# Altium schematic sheet HANDSFREE_miniControl2.0.SchDoc
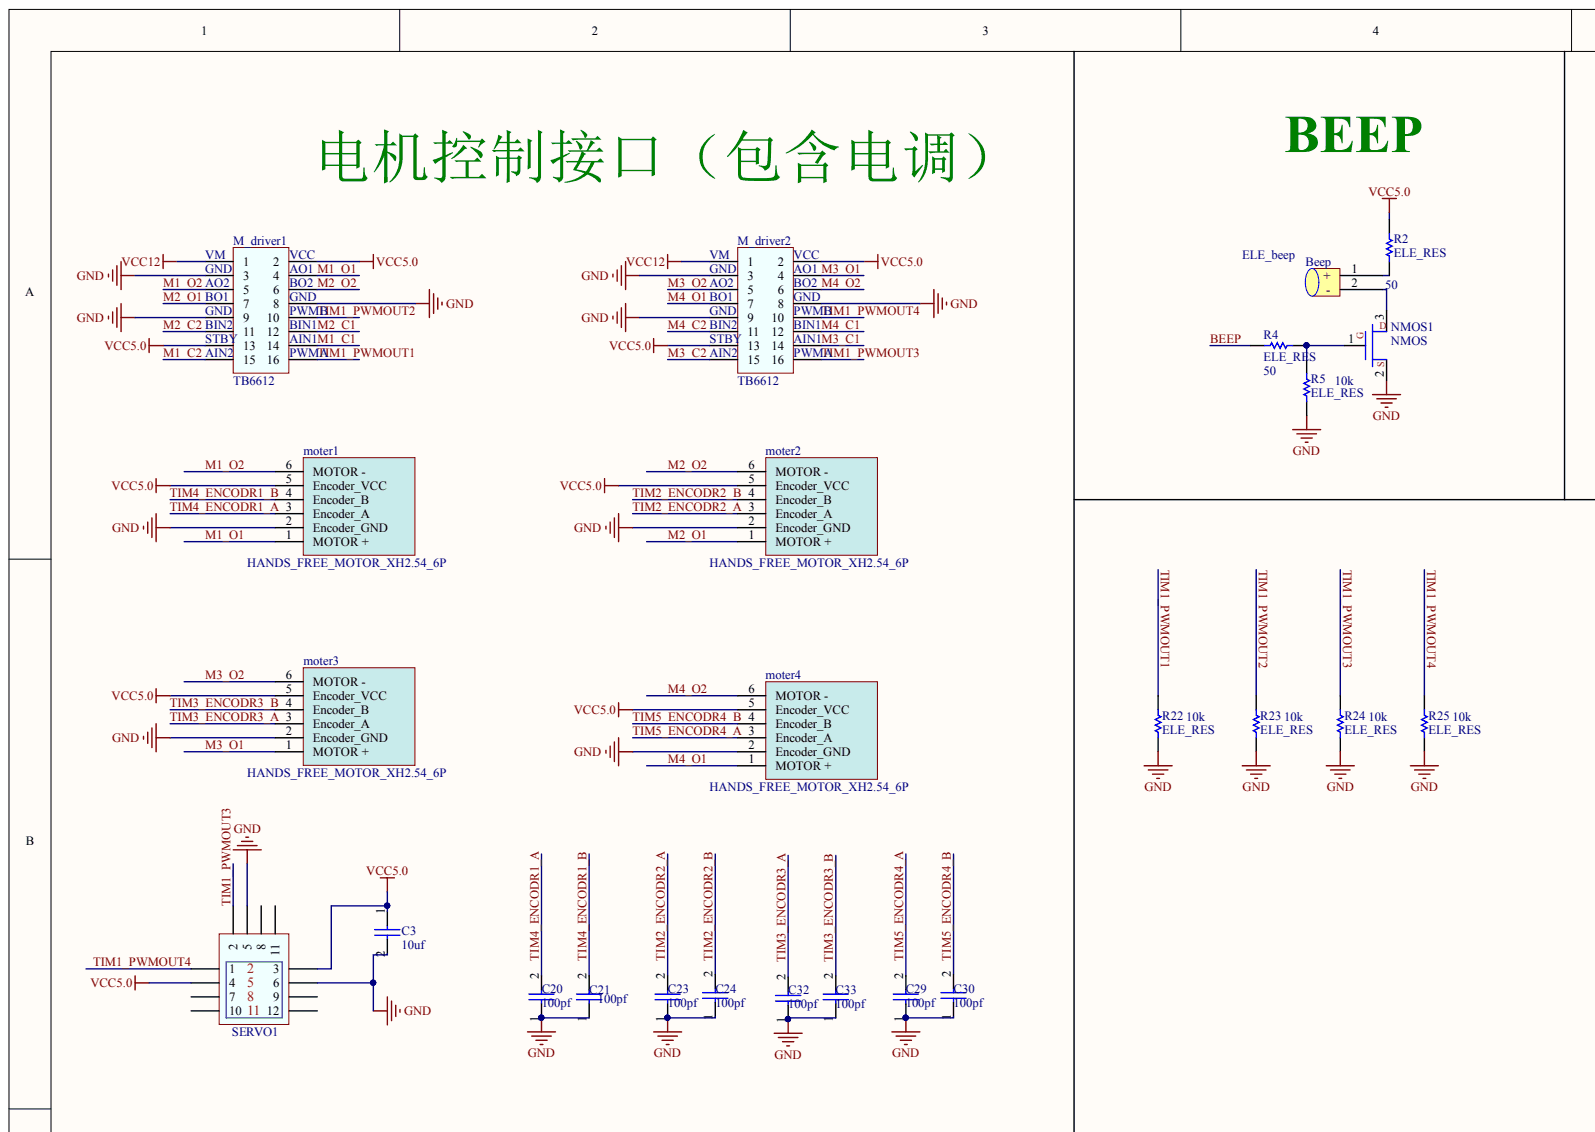
[diagram p0: HANDSFREE_miniControl2.0.SchDoc, top left]
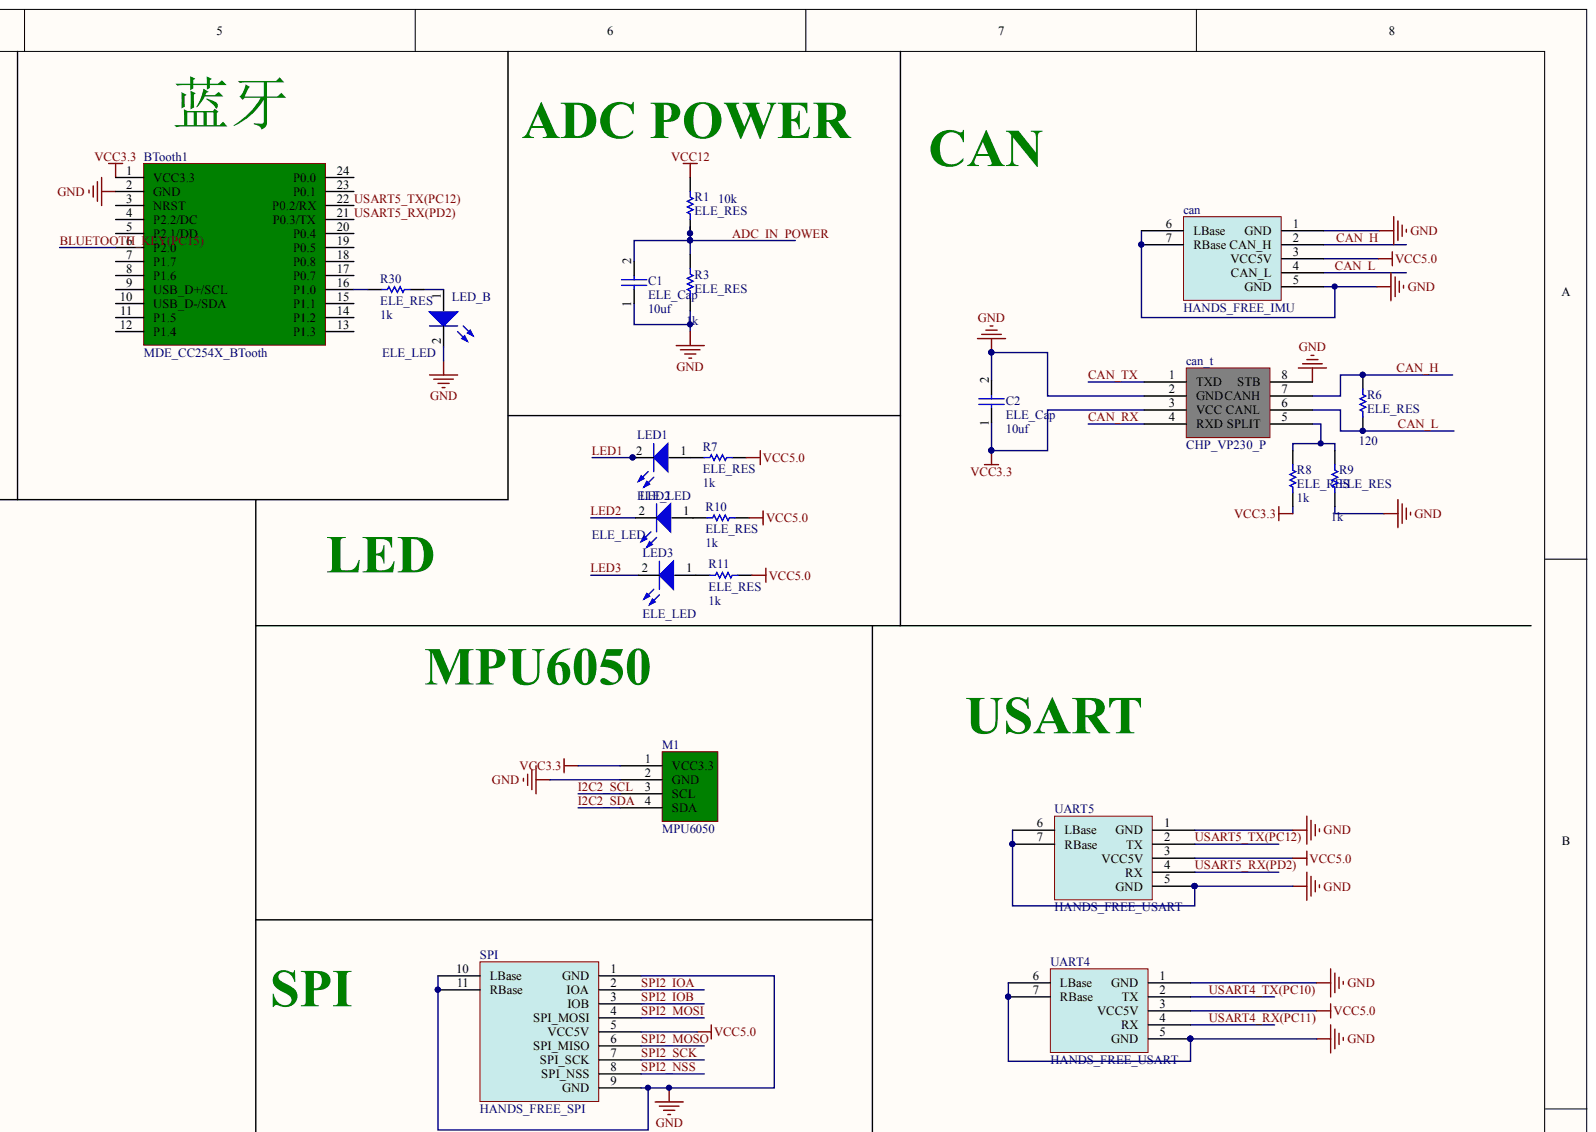
[diagram p1: HANDSFREE_miniControl2.0.SchDoc, top right]
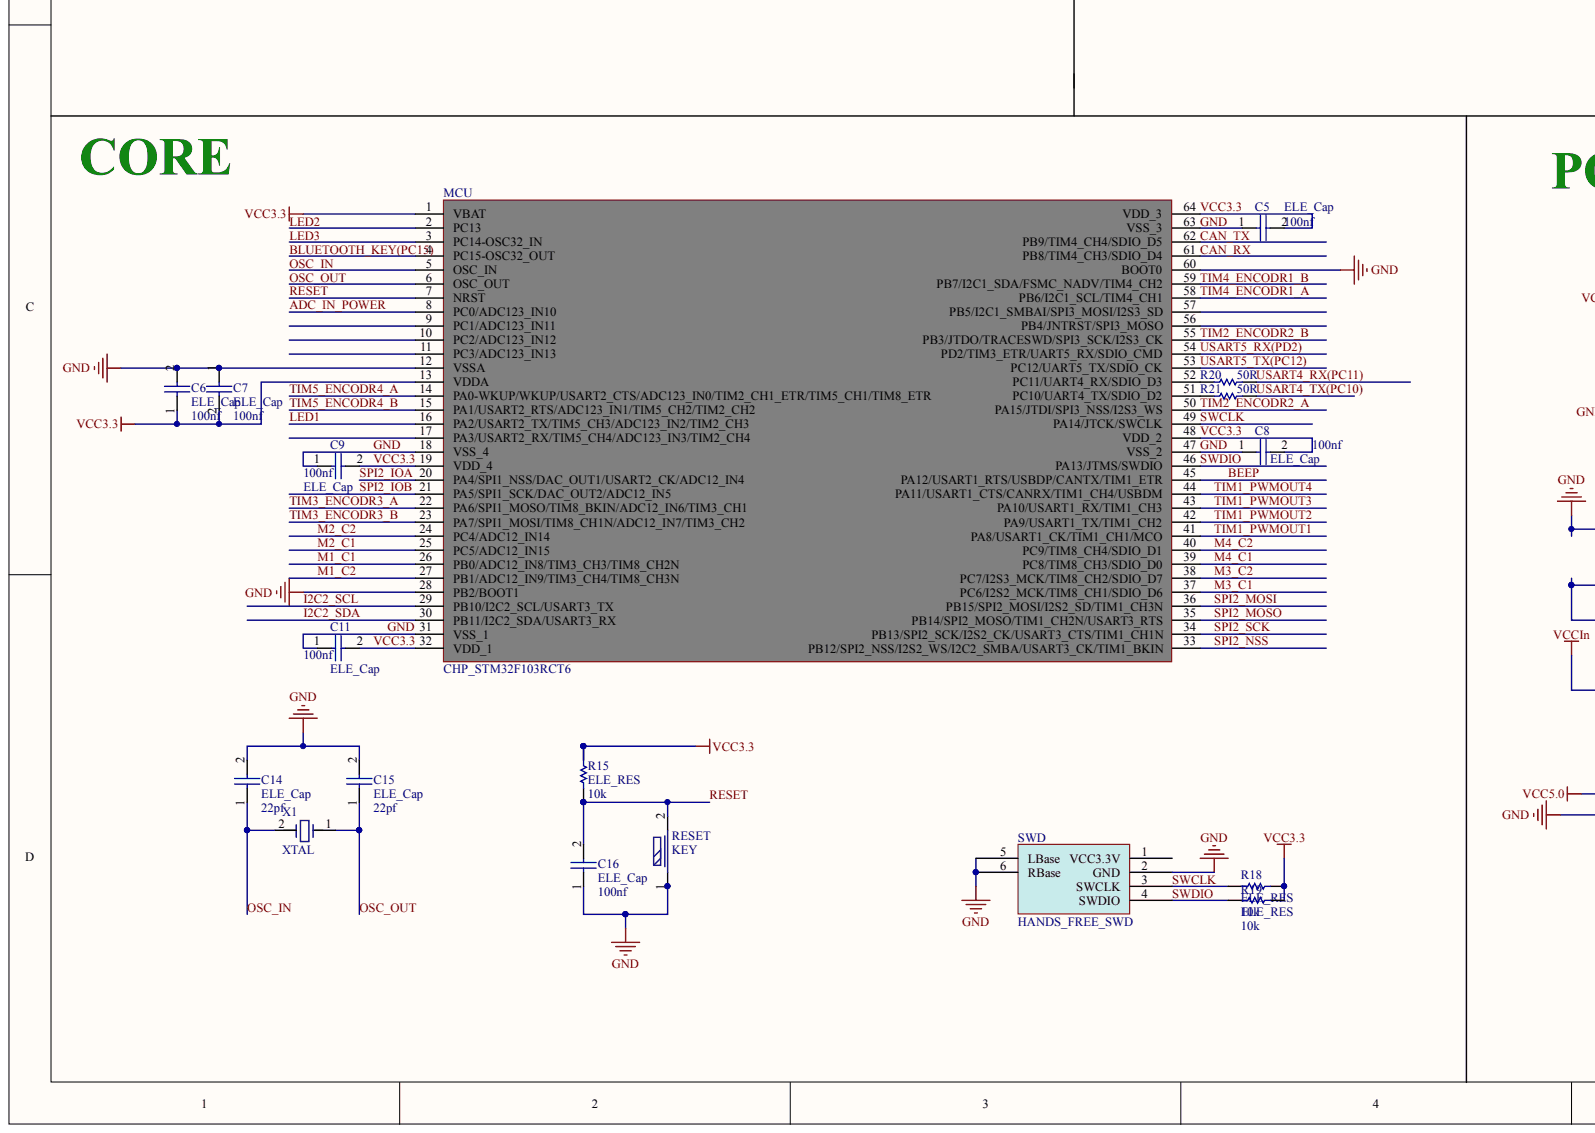
[diagram p2: HANDSFREE_miniControl2.0.SchDoc, bottom left]
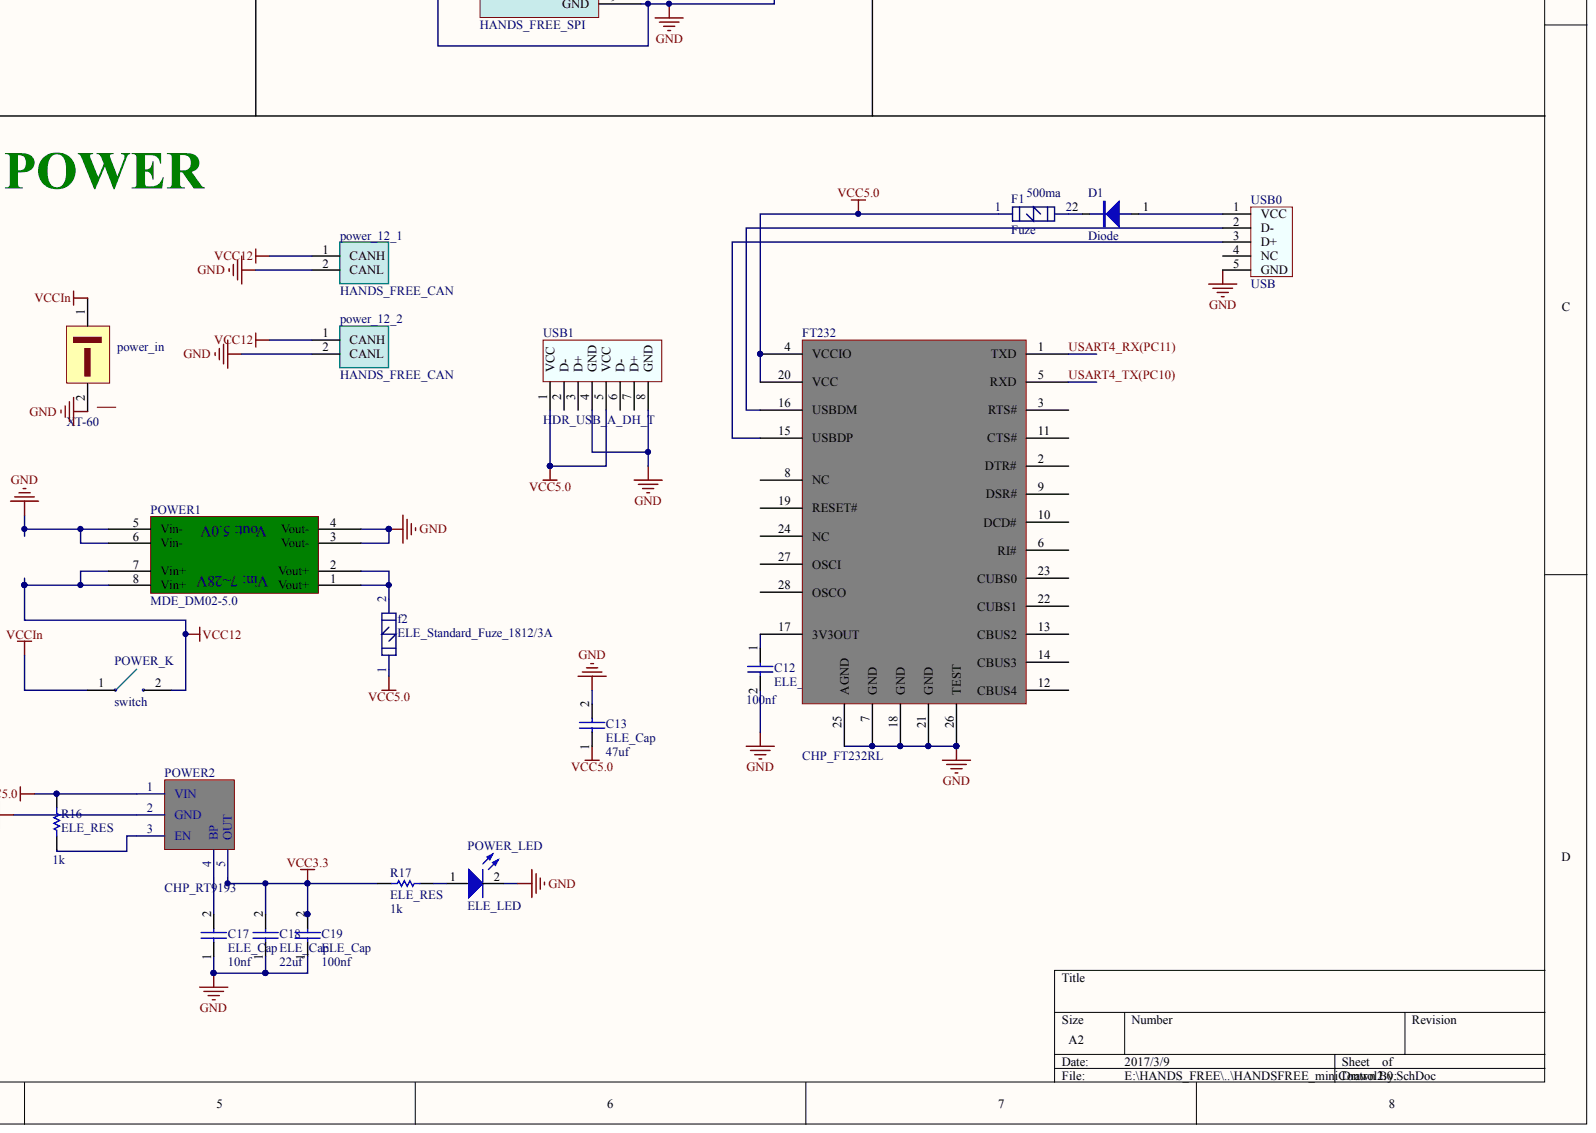
[diagram p3: HANDSFREE_miniControl2.0.SchDoc, bottom right]

## Parts
Beep  ELE_beep
BTooth1  MDE_CC254X_BTooth
C1  ELE_Cap  value 10uf
C2  ELE_Cap  value 10uf
C3  10uf
C5  ELE_Cap
C6  ELE_Cap  value 100nf
C7  ELE_Cap  value 100nf
C8  ELE_Cap  value 100nf
C9  ELE_Cap  value 100nf
C11  ELE_Cap  value 100nf
C12  ELE_Cap  value 100nf
C13  ELE_Cap  value 47uf
C14  ELE_Cap  value 22pf
C15  ELE_Cap  value 22pf
C16  ELE_Cap  value 100nf
C17  ELE_Cap  value 10nf
C18  ELE_Cap  value 22uf
C19  ELE_Cap  value 100nf
C20  100pf
C21  100pf
C23  100pf
C24  100pf
C29  100pf
C30  100pf
C32  100pf
C33  100pf
can  HANDS_FREE_IMU
can_t  CHP_VP230_P
D1  Diode
F1  Fuze  value 500ma
f2  ELE_Standard_Fuze_1812/3A
FT232  CHP_FT232RL
LED1  ELE_LED
LED2  ELE_LED
LED3  ELE_LED
LED_B  ELE_LED
M1  MPU6050
M_driver1  TB6612
M_driver2  TB6612
MCU  CHP_STM32F103RCT6
moter1  HANDS_FREE_MOTOR_XH2.54_6P
moter2  HANDS_FREE_MOTOR_XH2.54_6P
moter3  HANDS_FREE_MOTOR_XH2.54_6P
moter4  HANDS_FREE_MOTOR_XH2.54_6P
NMOS1  NMOS
POWER1  MDE_DM02-5.0
POWER2  CHP_RT9193
power_12_1  HANDS_FREE_CAN
power_12_2  HANDS_FREE_CAN
power_in  TPlug  (value not drawn)
POWER_K  switch
POWER_LED  ELE_LED
R1  ELE_RES  value 10k
R2  ELE_RES  value 50
R3  ELE_RES  value 1k
R4  ELE_RES  value 50
R5  ELE_RES  value 10k
R6  ELE_RES  value 120
R7  ELE_RES  value 1k
R8  ELE_RES  value 1k
R9  ELE_RES  value 1k
R10  ELE_RES  value 1k
R11  ELE_RES  value 1k
R15  ELE_RES  value 10k
R16  ELE_RES  value 1k
R17  ELE_RES  value 1k
R18  ELE_RES  value 10k
R19  ELE_RES  value 10k
R20  50R
R21  50R
R22  ELE_RES  value 10k
R23  ELE_RES  value 10k
R24  ELE_RES  value 10k
R25  ELE_RES  value 10k
R30  ELE_RES  value 1k
RESET  KEY
SERVO1  HDR_Header_4*3  (value not drawn)
SPI  HANDS_FREE_SPI
SWD  HANDS_FREE_SWD
UART4  HANDS_FREE_USART
UART5  HANDS_FREE_USART
USB0  USB
USB1  HDR_USB_A_DH_T
X1  XTAL

## Nets
VCC5.0: C3.1 C13.1 can.3(VCC5V) F1.1 f2.1 FT232.4(VCCIO) FT232.20(VCC) M_driver1.2 M_driver1.13 M_driver2.2 M_driver2.13 moter1.5(Encoder_VCC) moter2.5(Encoder_VCC) moter3.5(Encoder_VCC) moter4.5(Encoder_VCC) POWER2.1(VIN) R2.1 R7.2 R10.2 R11.2 R16.1 SERVO1.3 SERVO1.4 SPI.5(VCC5V) UART4.3(VCC5V) UART5.3(VCC5V) USB1.1(VCC) USB1.5(VCC)
VCC12: M_driver1.1 M_driver2.1 POWER1.7(Vin+) POWER1.8(Vin+) power_12_1.1 power_12_2.1 POWER_K.2 R1.2
VCCIN: power_in.1 POWER_K.1
ADC_IN_POWER: C1.2 MCU.8(PC0/ADC123_IN10) R1.1 R3.1
BEEP: MCU.45(PA12/USART1_RTS/USBDP/CANTX/TIM1_ETR) R4.1
BLUETOOTH_KEY(PC15): BTooth1.6(P2.0) MCU.4(PC15-OSC32_OUT)
CAN_H: can.2(CAN_H) can_t.7(CANH) R6.1
CAN_L: can.4(CAN_L) can_t.6(CANL) R6.2
CAN_RX: can_t.4(RXD) MCU.61(PB8/TIM4_CH3/SDIO_D4)
CAN_TX: can_t.1(TXD) MCU.62(PB9/TIM4_CH4/SDIO_D5)
GND: BTooth1.2(GND) C1.1 C2.2 C3.2 C5.1 C6.2 C7.1 C8.1 C9.1 C11.1 C12.2 C13.2 C14.2 C15.2 C16.1 C17.1 C18.1 C19.1 C20.1 C21.1 C23.1 C24.1 C29.1 C30.1 C32.1 C33.1 can.1(GND) can.5(GND) can.6(LBase) can.7(RBase) can_t.2(GND) can_t.8(STB) FT232.7(GND) FT232.18(GND) FT232.21(GND) FT232.25(AGND) FT232.26(TEST) LED_B.2 M1.2(GND) M_driver1.3 M_driver1.8 M_driver1.9 M_driver2.3 M_driver2.8 M_driver2.9 MCU.12(VSSA) MCU.18(VSS_4) MCU.28(PB2/BOOT1) MCU.31(VSS_1) MCU.47(VSS_2) MCU.60(BOOT0) MCU.63(VSS_3) moter1.2(Encoder_GND) moter2.2(Encoder_GND) moter3.2(Encoder_GND) moter4.2(Encoder_GND) NMOS1.2 POWER1.3(Vout-) POWER1.4(Vout-) POWER1.5(Vin-) POWER1.6(Vin-) POWER2.2(GND) power_12_1.2 power_12_2.2 power_in.2 POWER_LED.2 R3.2 R5.1 R9.2 R22.1 R23.1 R24.1 R25.1 RESET.1 SERVO1.5 SERVO1.6 SPI.1(GND) SPI.9(GND) SPI.10(LBase) SPI.11(RBase) SWD.2(GND) SWD.5(LBase) SWD.6(RBase) UART4.1(GND) UART4.5(GND) UART4.6(LBase) UART4.7(RBase) UART5.1(GND) UART5.5(GND) UART5.6(LBase) UART5.7(RBase) USB0.5(GND) USB1.4(GND) USB1.8(GND)
I2C2_SCL: M1.3(SCL) MCU.29(PB10/I2C2_SCL/USART3_TX)
I2C2_SDA: M1.4(SDA) MCU.30(PB11/I2C2_SDA/USART3_RX)
LED1: LED1.2 MCU.16(PA2/USART2_TX/TIM5_CH3/ADC123_IN2/TIM2_CH3)
LED2: LED2.2 MCU.2(PC13)
LED3: LED3.2 MCU.3(PC14-OSC32_IN)
M1_C1: M_driver1.14 MCU.26(PB0/ADC12_IN8/TIM3_CH3/TIM8_CH2N)
M1_C2: M_driver1.15 MCU.27(PB1/ADC12_IN9/TIM3_CH4/TIM8_CH3N)
M1_O1: M_driver1.4 moter1.1(MOTOR_+)
M1_O2: M_driver1.5 moter1.6(MOTOR_-)
M2_C1: M_driver1.12 MCU.25(PC5/ADC12_IN15)
M2_C2: M_driver1.11 MCU.24(PC4/ADC12_IN14)
M2_O1: M_driver1.7 moter2.1(MOTOR_+)
M2_O2: M_driver1.6 moter2.6(MOTOR_-)
M3_C1: M_driver2.14 MCU.37(PC6/I2S2_MCK/TIM8_CH1/SDIO_D6)
M3_C2: M_driver2.15 MCU.38(PC7/I2S3_MCK/TIM8_CH2/SDIO_D7)
M3_O1: M_driver2.4 moter3.1(MOTOR_+)
M3_O2: M_driver2.5 moter3.6(MOTOR_-)
M4_C1: M_driver2.12 MCU.39(PC8/TIM8_CH3/SDIO_D0)
M4_C2: M_driver2.11 MCU.40(PC9/TIM8_CH4/SDIO_D1)
M4_O1: M_driver2.7 moter4.1(MOTOR_+)
M4_O2: M_driver2.6 moter4.6(MOTOR_-)
OSC_IN: C14.1 MCU.5(OSC_IN) X1.2
OSC_OUT: C15.1 MCU.6(OSC_OUT) X1.1
RESET: C16.2 MCU.7(NRST) R15.2 RESET.2
SPI2_IOA: MCU.20(PA4/SPI1_NSS/DAC_OUT1/USART2_CK/ADC12_IN4) SPI.2(IOA)
SPI2_IOB: MCU.21(PA5/SPI1_SCK/DAC_OUT2/ADC12_IN5) SPI.3(IOB)
SPI2_MOSI: MCU.36(PB15/SPI2_MOSI/I2S2_SD/TIM1_CH3N) SPI.4(SPI_MOSI)
SPI2_MOSO: MCU.35(PB14/SPI2_MOSO/TIM1_CH2N/USART3_RTS) SPI.6(SPI_MISO)
SPI2_NSS: MCU.33(PB12/SPI2_NSS/I2S2_WS/I2C2_SMBA/USART3_CK/TIM1_BKIN) SPI.8(SPI_NSS)
SPI2_SCK: MCU.34(PB13/SPI2_SCK/I2S2_CK/USART3_CTS/TIM1_CH1N) SPI.7(SPI_SCK)
SWCLK: MCU.49(PA14/JTCK/SWCLK) R18.2 SWD.3(SWCLK)
SWDIO: MCU.46(PA13/JTMS/SWDIO) R19.2 SWD.4(SWDIO)
TIM1_PWMOUT1: M_driver1.16 MCU.41(PA8/USART1_CK/TIM1_CH1/MCO) R22.2
TIM1_PWMOUT2: M_driver1.10 MCU.42(PA9/USART1_TX/TIM1_CH2) R23.2
TIM1_PWMOUT3: M_driver2.16 MCU.43(PA10/USART1_RX/TIM1_CH3) R24.2 SERVO1.2
TIM1_PWMOUT4: M_driver2.10 MCU.44(PA11/USART1_CTS/CANRX/TIM1_CH4/USBDM) R25.2 SERVO1.1
TIM2_ENCODR2_A: C23.2 MCU.50(PA15/JTDI/SPI3_NSS/I2S3_WS) moter2.3(Encoder_A)
TIM2_ENCODR2_B: C24.2 MCU.55(PB3/JTDO/TRACESWD/SPI3_SCK/I2S3_CK) moter2.4(Encoder_B)
TIM3_ENCODR3_A: C32.2 MCU.22(PA6/SPI1_MOSO/TIM8_BKIN/ADC12_IN6/TIM3_CH1) moter3.3(Encoder_A)
TIM3_ENCODR3_B: C33.2 MCU.23(PA7/SPI1_MOSI/TIM8_CH1N/ADC12_IN7/TIM3_CH2) moter3.4(Encoder_B)
TIM4_ENCODR1_A: C20.2 MCU.58(PB6/I2C1_SCL/TIM4_CH1) moter1.3(Encoder_A)
TIM4_ENCODR1_B: C21.2 MCU.59(PB7/I2C1_SDA/FSMC_NADV/TIM4_CH2) moter1.4(Encoder_B)
TIM5_ENCODR4_A: C29.2 MCU.14(PA0-WKUP/WKUP/USART2_CTS/ADC123_IN0/TIM2_CH1_ETR/TIM5_CH1/TIM8_ETR) moter4.3(Encoder_A)
TIM5_ENCODR4_B: C30.2 MCU.15(PA1/USART2_RTS/ADC123_IN1/TIM5_CH2/TIM2_CH2) moter4.4(Encoder_B)
USART4_RX(PC11): FT232.1(TXD) R20.1 UART4.4(RX)
USART4_TX(PC10): FT232.5(RXD) R21.1 UART4.2(TX)
USART5_RX(PD2): BTooth1.21(P0.3/TX) MCU.54(PD2/TIM3_ETR/UART5_RX/SDIO_CMD) UART5.4(RX)
USART5_TX(PC12): BTooth1.22(P0.2/RX) MCU.53(PC12/UART5_TX/SDIO_CK) UART5.2(TX)
VCC3.3: BTooth1.1(VCC3.3) C2.1 C5.2 C6.1 C7.2 C8.2 C9.2 C11.2 C18.2 C19.2 can_t.3(VCC) M1.1(VCC3.3) MCU.1(VBAT) MCU.13(VDDA) MCU.19(VDD_4) MCU.32(VDD_1) MCU.48(VDD_2) MCU.64(VDD_3) POWER2.5(OUT) R8.1 R15.1 R17.1 R18.1 R19.1
NetBeep_1: Beep.1 R2.2
NetBeep_2: Beep.2 NMOS1.3
NetBTooth1_16: BTooth1.16(P1.0) R30.1
NetC12_1: C12.1 FT232.17(3V3OUT)
NetC17_2: C17.2 POWER2.4(BP)
Netcan_t_5: can_t.5(SPLIT) R8.2 R9.1
NetD1_1: D1.1 USB0.1(VCC)
NetD1_2: D1.2 F1.2
Netf2_2: f2.2 POWER1.1(Vout+) POWER1.2(Vout+)
NetFT232_15: FT232.15(USBDP) USB0.3(D+)
NetFT232_16: FT232.16(USBDM) USB0.2(D-)
NetLED1_1: LED1.1 R7.1
NetLED2_1: LED2.1 R10.1
NetLED3_1: LED3.1 R11.1
NetLED_B_1: LED_B.1 R30.2
NetMCU_51: MCU.51(PC10/UART4_TX/SDIO_D2) R21.2
NetMCU_52: MCU.52(PC11/UART4_RX/SDIO_D3) R20.2
NetNMOS1_1: NMOS1.1 R4.2 R5.2
NetPOWER2_3: POWER2.3(EN) R16.2
NetPOWER_LED_1: POWER_LED.1 R17.2

Not connected: BTooth1 3(NRST) 4(P2.2/DC) 5(P2.1/DD) 7(P1.7) 8(P1.6) 9(USB_D+/SCL) 10(USB_D-/SDA) 11(P1.5) 12(P1.4) 13(P1.3) 14(P1.2) 15(P1.1) 17(P0.7) 18(P0.8) 19(P0.5) 20(P0.4) 23(P0.1) 24(P0.0); FT232 2(DTR#) 3(RTS#) 6(RI#) 8(NC) 9(DSR#) 10(DCD#) 11(CTS#) 12(CBUS4) 13(CBUS2) 14(CBUS3) 19(RESET#) 22(CUBS1) 23(CUBS0) 24(NC) 27(OSCI) 28(OSCO); MCU 9(PC1/ADC123_IN11) 10(PC2/ADC123_IN12) 11(PC3/ADC123_IN13) 17(PA3/USART2_RX/TIM5_CH4/ADC123_IN3/TIM2_CH4) 56(PB4/JNTRST/SPI3_MOSO) 57(PB5/I2C1_SMBAI/SPI3_MOSI/I2S3_SD); SERVO1 7 8 9 10 11 12; SWD 1(VCC3.3V); USB0 4(NC); USB1 2(D-) 3(D+) 6(D-) 7(D+)

## Library data of the parts
C5 = (no symbol name): VALUE: 100nf
NMOS1 = (no symbol name): Note: Pin 2 is electrically connected to pin 4.; Published: 8-Jun-2000; LatestRevisionDate: 17-Jul-2002; LatestRevisionNote: Re-released for DXP Platform.; DatasheetDocument: PD-9.814; PackageReference: TO-220AB; Code_JEDEC: TO-220-AB; ComponentLink1Description: Manufacturer Link; ComponentLink1URL: http://www.irf.com/; Publisher: Altium Limited
R5 R18 = (no symbol name): VALUE: 10k
R7 = (no symbol name): VALUE: 1k
Beep BTooth1 C1-C3 C6-C9 C11-C21 C23 C24 C29 C30 C32 C33 can can_t D1 F1 f2 FT232 LED1-LED3 LED_B M1 M_driver1 M_driver2 MCU moter1-moter4 POWER1 POWER2 power_12_1 power_12_2 power_in POWER_K POWER_LED R1-R4 R6 R8-R11 R15-R17 R19-R25 R30 RESET SERVO1 SPI SWD UART4 UART5 USB0 USB1 X1 = (no symbol name)
  LED1-LED3 LED_B POWER_LED: Published: 8-Jun-2000; LatestRevisionDate: 17-Jul-2002; LatestRevisionNote: Re-released for DXP Platform.; PackageDocument: Feb-2002; PackageReference: 3.2X1.6X1.1
  M_driver1 M_driver2: LatestRevisionDate: 17-Jul-2002; LatestRevisionNote: Re-released for DXP Platform.
  power_in: : XT-60
  LED1-LED3 LED_B M_driver1 M_driver2 POWER_LED: Publisher: Altium Limited
